# Altium schematic sheet Serial Monitor GPIO Module Schematic.SchDoc("Serial Monitor GPIO Module Schematic")
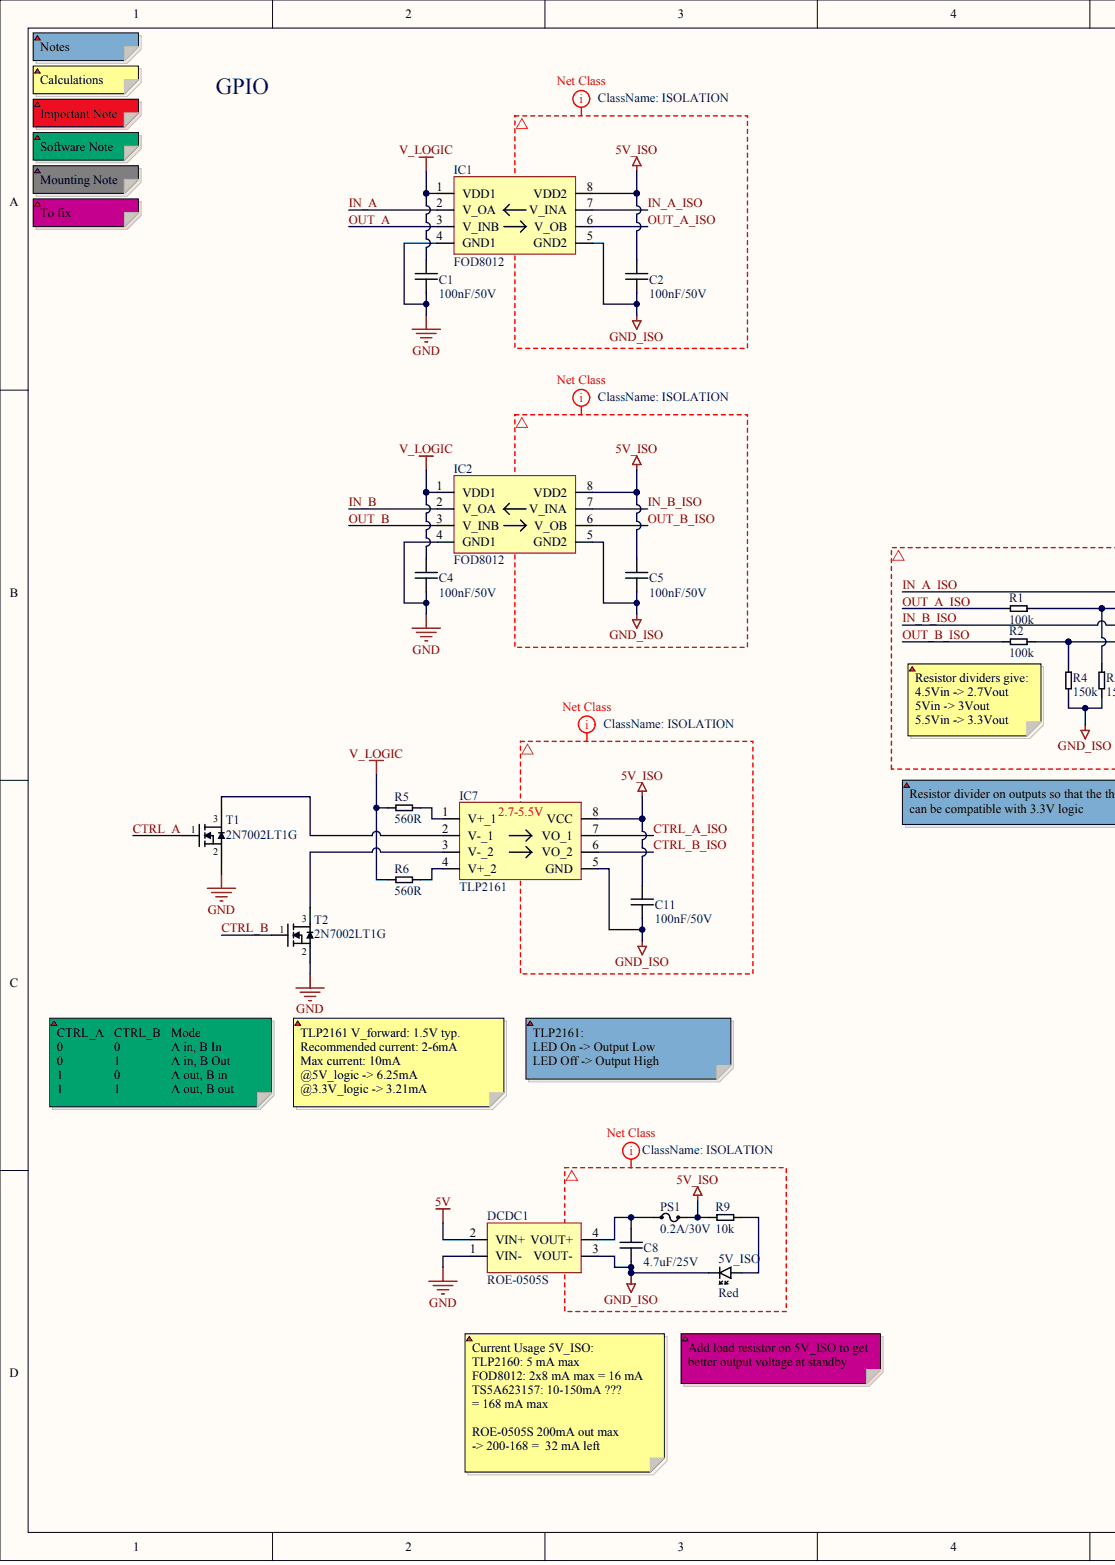
[diagram p0: Serial Monitor GPIO Module Schematic.SchDoc, left]
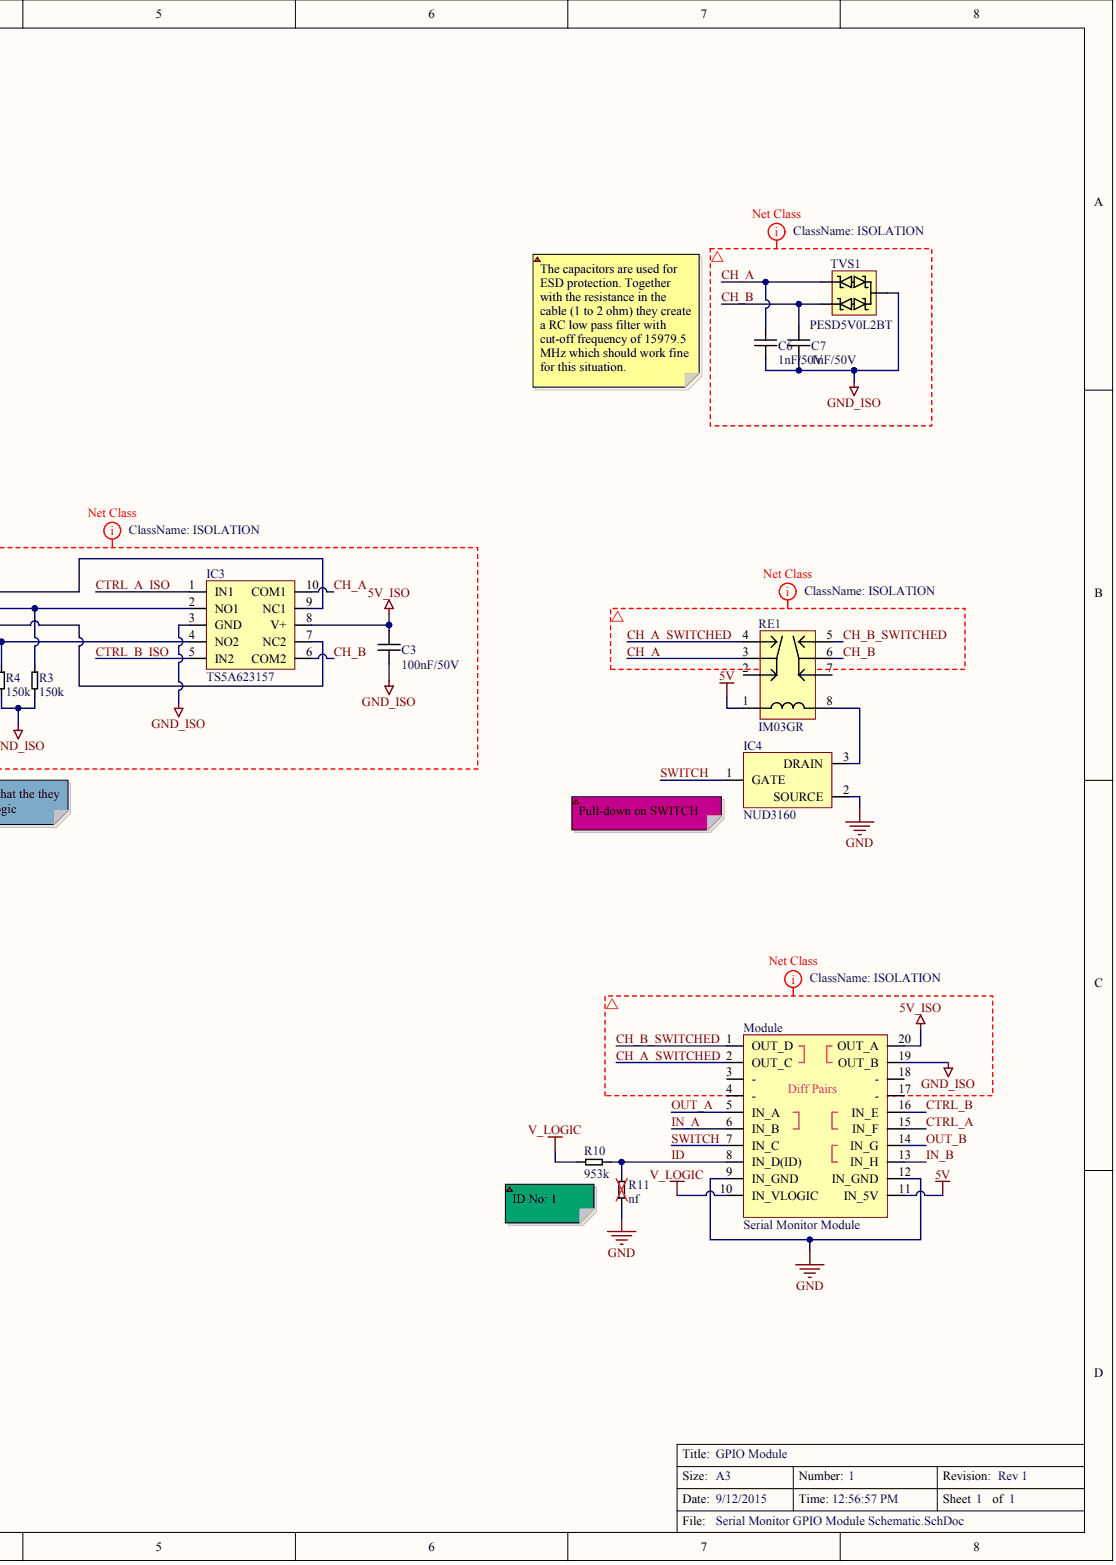
[diagram p1: Serial Monitor GPIO Module Schematic.SchDoc, right]

## Parts
5V_ISO  value Red  (hidden comment: LED_0603_Red)
C1  value 100nF/50V  [0603]  (hidden comment: Cap_0603_100nF/50V)
C2  value 100nF/50V  [0603]  (hidden comment: Cap_0603_100nF/50V)
C3  value 100nF/50V  [0603]  (hidden comment: Cap_0603_100nF/50V)
C4  value 100nF/50V  [0603]  (hidden comment: Cap_0603_100nF/50V)
C5  value 100nF/50V  [0603]  (hidden comment: Cap_0603_100nF/50V)
C6  value 1nF/50V  [0603]  (hidden comment: Cap_0603_1nF/50V)
C7  value 1nF/50V  [0603]  (hidden comment: Cap_0603_1nF/50V)
C8  value 4.7uF/25V  [0805]  (hidden comment: Cap_0805_4.7uF/25V)
C11  value 100nF/50V  [0603]  (hidden comment: Cap_0603_100nF/50V)
DCDC1  ROE-0505S  [4-SIP]
IC1  FOD8012
IC2  FOD8012
IC3  TS5A623157
IC4  NUD3160
IC7  TLP2161
Module  Serial Monitor Module
PS1  value 0.2A/30V  [SMD 1206]  (hidden comment: Polyswitch_1206_0.2Ahold_30V)
R1  value 100k  [0603]  (hidden comment: Res_0603_100k)
R2  value 100k  [0603]  (hidden comment: Res_0603_100k)
R3  value 150k  [0603]  (hidden comment: Res_0603_150k)
R4  value 150k  [0603]  (hidden comment: Res_0603_150k)
R5  value 560R  [0603]  (hidden comment: Res_0603_560R)
R6  value 560R  [0603]  (hidden comment: Res_0603_560R)
R9  value 10k  [0603]  (hidden comment: Res_0603_10k)
R10  value 953k  [0603]  (hidden comment: Res_0603_953k)
R11  value nf  [0603]  (hidden comment: Res_0603_nf)
RE1  value IM03GR  Part No.: IM03GR  (hidden comment: Relay IM03GR)
T1  2N7002LT1G  [SOT-23]
T2  2N7002LT1G  [SOT-23]
TVS1  PESD5V0L2BT

## Nets
5V: DCDC1.2(VIN+) Module.11(IN_5V) RE1.1
5V_ISO: C2.2 C3.2 C5.2 C11.2 IC1.8(VDD2) IC2.8(VDD2) IC3.8(V+) IC7.8(VCC) Module.20(OUT_A) PS1.2 R9.2
GND: C1.1 C4.1 DCDC1.1(VIN-) IC1.4(GND1) IC2.4(GND1) IC4.2 Module.9(IN_GND) Module.12(IN_GND) R11.2 T1.2 T2.2
GND_ISO: 5V_ISO.CATHODE C2.1 C3.1 C5.1 C6.1 C7.2 C8.1 C11.1 DCDC1.3(VOUT-) IC1.5(GND2) IC2.5(GND2) IC3.3(GND) IC7.5(GND) Module.19(OUT_B) R3.1 R4.1 TVS1.3
V_LOGIC: C1.2 C4.2 IC1.1(VDD1) IC2.1(VDD1) Module.10(IN_VLOGIC) R5.1 R6.1 R10.2
CH_A: C6.2 IC3.10(COM1) RE1.3 TVS1.2
CH_A_SWITCHED: Module.2(OUT_C) RE1.4
CH_B: C7.1 IC3.6(COM2) RE1.6 TVS1.1
CH_B_SWITCHED: Module.1(OUT_D) RE1.5
CTRL_A: Module.15(IN_F) T1.1
CTRL_A_ISO: IC3.1(IN1) IC7.7(VO_1)
CTRL_B: Module.16(IN_E) T2.1
CTRL_B_ISO: IC3.5(IN2) IC7.6(VO_2)
ID: Module.8(IN_D(ID)) R10.1 R11.1
IN_A: IC1.2(V_OA) Module.6(IN_B)
IN_A_ISO: IC1.7(V_INA) IC3.9(NC1)
IN_B: IC2.2(V_OA) Module.13(IN_H)
IN_B_ISO: IC2.7(V_INA) IC3.7(NC2)
OUT_A: IC1.3(V_INB) Module.5(IN_A)
OUT_A_ISO: IC1.6(V_OB) R1.1
OUT_B: IC2.3(V_INB) Module.14(IN_G)
OUT_B_ISO: IC2.6(V_OB) R2.1
SWITCH: IC4.1 Module.7(IN_C)
Net5V_ISO_ANODE: 5V_ISO.ANODE R9.1
NetC8_2: C8.2 DCDC1.4(VOUT+) PS1.1
NetIC3_2: IC3.2(NO1) R1.2 R3.2
NetIC3_4: IC3.4(NO2) R2.2 R4.2
NetIC4_3: IC4.3 RE1.8
NetIC7_1: IC7.1(V+_1) R5.2
NetIC7_2: IC7.2(V-_1) T1.3
NetIC7_3: IC7.3(V-_2) T2.3
NetIC7_4: IC7.4(V+_2) R6.2
N00033:
N00034:
N00035:
N00036:
N00037:
N00038:
N00039:
N00040:

Not connected: Module 3(-) 4(-) 17(-) 18(-); RE1 2 7

## Library data of the parts
5V_ISO = (no symbol name): Part No.: APT1608SRCPRV; Manufacturer: Kingbright; RS: 654-5420; DigiKey: 754-1122-1-ND; Price SEK (No VAT): 1; Mouser: 604-APT1608SRCPRV
C1-C5 C11 = (no symbol name): Part No.: C1608X7R1H104K080AA; Manufacturer: TDK; RS: 741-4459 (500-pack); DigiKey: 445-1314-1-ND; Mouser: 810-C1608X7R1H104K; Price SEK (No VAT): 0,2
C6 C7 = (no symbol name): Part No.: C1608X7R1H102K080AE; Manufacturer: TDK; Mouser: 810-C1608X7R1H102KAE; DigiKey: 445-15923-1-ND; Price SEK (No VAT): 0,4
C8 = (no symbol name): Part No.: GRM21BR61E475KA12L; Manufacturer: Murata; DigiKey: 490-3335-1-ND; Mouser: 81-GRM21BR61E475KA12; Price SEK (No VAT): 1
DCDC1 = (no symbol name): Manufacturer: Recom Power; DigiKey: 945-1655-5-ND; Part No.: ROE-0505S; Price SEK (No VAT): 20; Mouser: 919-ROE-0505S
IC1 IC2 = (no symbol name): Manufacturer: Fairchild Semiconductor; Note: Should be able to replace ADUM1201 as pin-compatible; DigiKey: FOD8012-ND; Part No.: FOD8012; Price SEK (No VAT): 31; Datasheet: http://www.fairchildsemi.com/ds/FO/FOD8012.pdf; Mouser: 512-FOD8012; Value: FOD8012
IC3 = (no symbol name): Part No.: TS5A623157DGSR; Manufacturer: TI; DigiKey: 296-22859-1-ND; Price SEK (No VAT): 7; Datasheet: http://www.ti.com/lit/ds/symlink/ts5a623157.pdf; Mouser: 595-TS5A623157DGSR; Value: TS5A623157
IC4 = (no symbol name): Part No.: NUD3160LT1G; Manufacturer: ON; DigiKey: NUD3160LT1GOSCT-ND; Price SEK (No VAT): 5; Datasheet: http://www.onsemi.com/pub_link/Collateral/NUD3160-D.PDF; Mouser: 863-NUD3160LT1G; Value: NUD3160
IC7 = (no symbol name): Manufacturer: Toshiba; Input on-state current: 2 - 6 mA; Forward voltage (typ): 1.5 V; DigiKey: TLP2161(F)-ND; Part No.: TLP2161; Price SEK (No VAT): 16; Datasheet: http://www.semicon.toshiba.co.jp/info/docget.jsp?type=datasheet&lang=en&pid=TLP2161; Mouser: 757-TLP2161F; Value: TLP2161
PS1 = (no symbol name): Part No.: 0ZCJ0020FF2E; Manufacturer: Bel Fuse; Mouser: 530-0ZCJ0020FF2E; Price SEK (No VAT): 1; DigiKey: 507-1797-1-ND; RS: *; Farnell: *
R1 R2 = (no symbol name): Part No.: CRCW0603100KFKEA; Manufacturer: Vishayp; Price SEK (No VAT): 0,1; Mouser: 71-CRCW0603-100K-E3; DigiKey: 541-100KHCT-ND
R3 R4 = (no symbol name): Part No.: CRCW0603150KFKEA; Manufacturer: Vishay; Price (No VAT): 0.1; DigiKey: 541-150KHCT-ND; Mouser: 71-CRCW0603-150K-E3
R5 R6 = (no symbol name): Part No.: CRCW0603560RFKEA; Manufacturer: Vishay; Price SEK (No VAT): 0,1; Mouser: 71-CRCW0603-560-E3; DigiKey: 541-560HCT-ND
R9 = (no symbol name): Part No.: CRCW060310K0FKEA; Manufacturer: Vishay; Price SEK (No VAT): 0,1; Mouser: 71-CRCW0603-10K-E3; DigiKey: 541-10.0KHCT-ND
R10 = (no symbol name): Part No.: CRCW0603953KFKEA; Manufacturer: Vishay; DigiKey: 541-953KHCT-ND; Mouser: 71-CRCW0603-953K-E3; Price SEK (No VAT): 0,1
RE1 = (no symbol name): Manufacturer: TE; Mouser: 655-IM03GR; DigiKey: PB1061CT-ND; Price SEK (No VAT): 28; Datasheet: http://www.te.com/commerce/DocumentDelivery/DDEController?Action=srchrtrv&DocNm=108-98001&DocType=SS&DocLang=EN
T1 T2 = (no symbol name): Manufacturer: ON Semiconductor; Mouser: 863-2N7002LT1G; Part No.: 2N7002LT1G; DigiKey: 2N7002LT1GOSCT-ND; Price SEK (No VAT): 2; Value: 2N7002LT1G
TVS1 = (no symbol name): Manufacturer: NXP; Part No.: PESD5V0L2BT,215; Mouser: 771-PESD5V0L2BT-T/R; DigiKey: 568-4050-1-ND; Price SEK (No VAT): 4; Value: PESD5V0L2BT
Module R11 = (no symbol name)
  Module: Value: Serial Monitor Module
  R11: Price SEK (No VAT): 0
